# Altium schematic sheet ASI_PCB.SchDoc
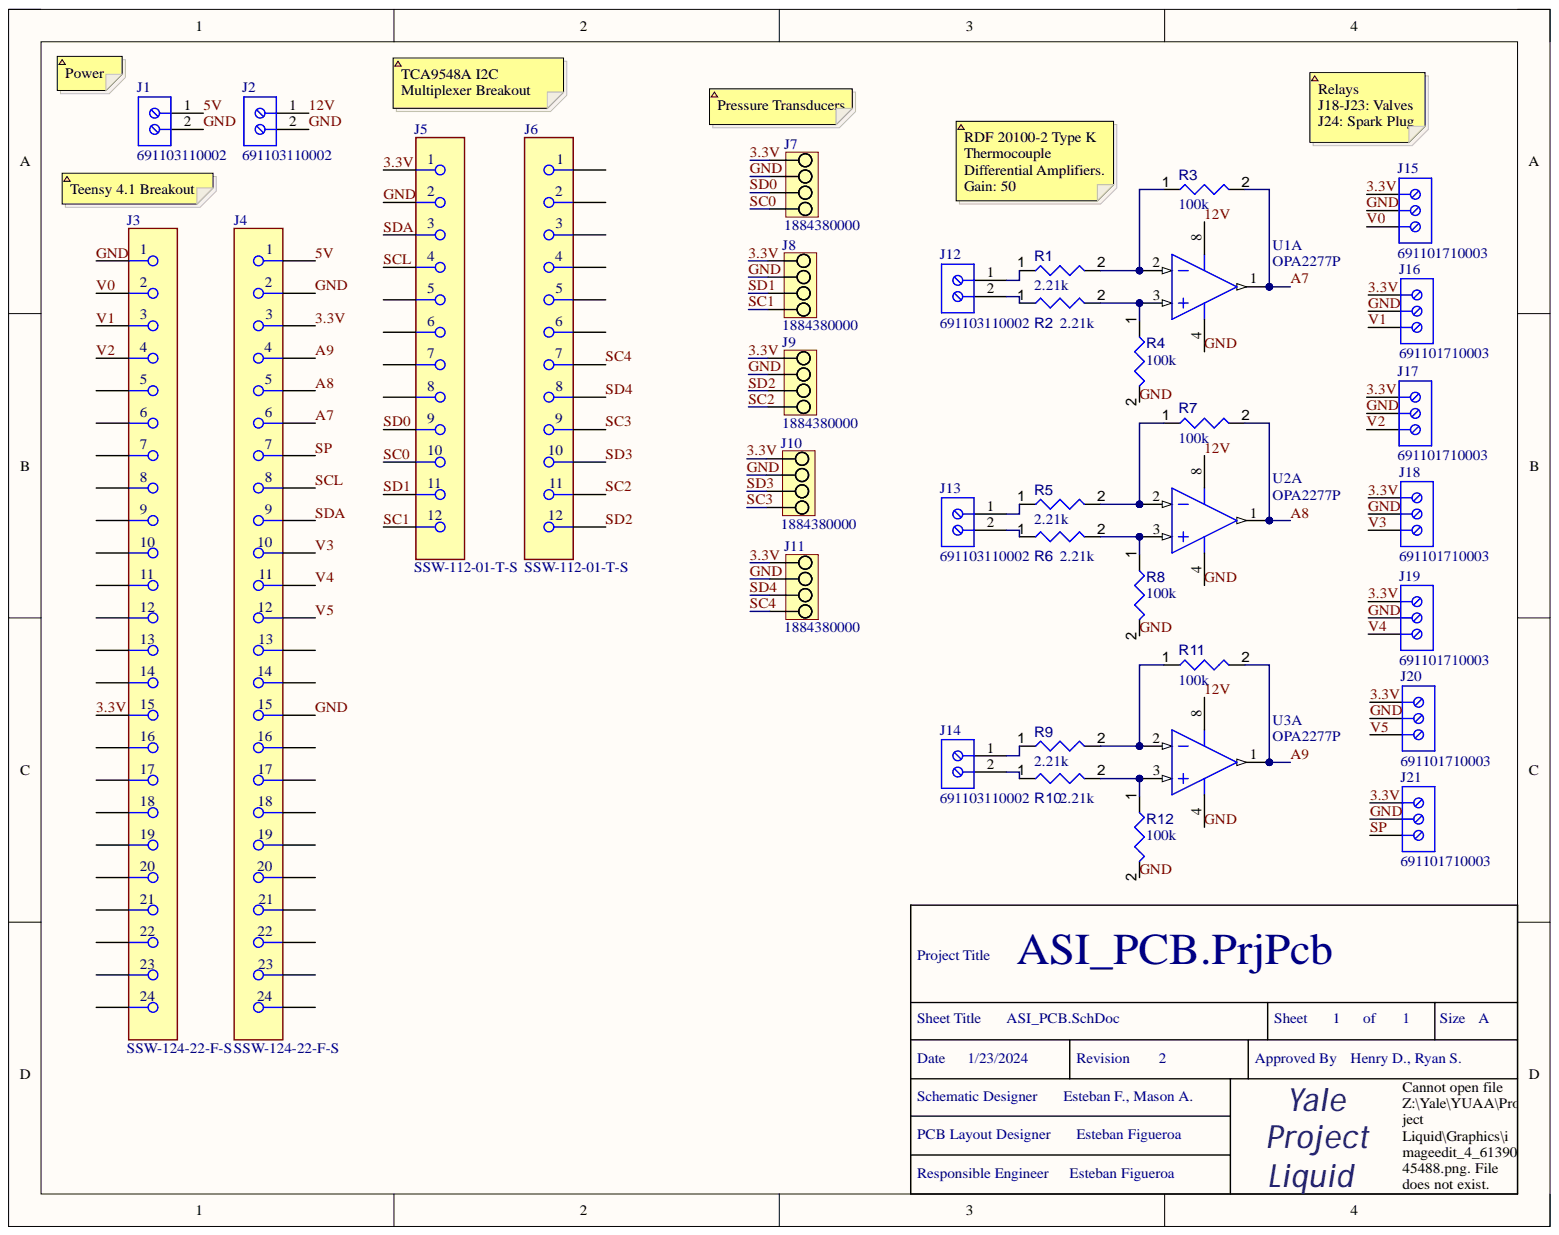
[diagram p0: ASI_PCB.SchDoc]

## Parts
J1  691103110002  [691103110002]
J2  691103110002  [691103110002]
J3  SSW-124-22-F-S  [FP-SSW-124-22-F-S-MFG]
J4  SSW-124-22-F-S  [FP-SSW-124-22-F-S-MFG]
J5  SSW-112-01-T-S  [FP-SSW-112-01-T-S-MFG]
J6  SSW-112-01-T-S  [FP-SSW-112-01-T-S-MFG]
J7  1884380000  [LSF_SMT_5_00_135_04]
J8  1884380000  [LSF_SMT_5_00_135_04]
J9  1884380000  [LSF_SMT_5_00_135_04]
J10  1884380000  [LSF_SMT_5_00_135_04]
J11  1884380000  [LSF_SMT_5_00_135_04]
J12  691103110002  [691103110002]
J13  691103110002  [691103110002]
J14  691103110002  [691103110002]
J15  691101710003  [691101710003]
J16  691101710003  [691101710003]
J17  691101710003  [691101710003]
J18  691101710003  [691101710003]
J19  691101710003  [691101710003]
J20  691101710003  [691101710003]
J21  691101710003  [691101710003]
R1  value 2.21k  [RESA60-630X240]  (hidden comment: MFR-25FBF52-2K21)
R2  value 2.21k  [RESA60-630X240]  (hidden comment: MFR-25FBF52-2K21)
R3  value 100k  [RESA60-630X240]  (hidden comment: MFR-25FBF52-100K)
R4  value 100k  [RESA60-630X240]  (hidden comment: MFR-25FBF52-100K)
R5  value 2.21k  [RESA60-630X240]  (hidden comment: MFR-25FBF52-2K21)
R6  value 2.21k  [RESA60-630X240]  (hidden comment: MFR-25FBF52-2K21)
R7  value 100k  [RESA60-630X240]  (hidden comment: MFR-25FBF52-100K)
R8  value 100k  [RESA60-630X240]  (hidden comment: MFR-25FBF52-100K)
R9  value 2.21k  [RESA60-630X240]  (hidden comment: MFR-25FBF52-2K21)
R10  value 2.21k  [RESA60-630X240]  (hidden comment: MFR-25FBF52-2K21)
R11  value 100k  [RESA60-630X240]  (hidden comment: MFR-25FBF52-100K)
R12  value 100k  [RESA60-630X240]  (hidden comment: MFR-25FBF52-100K)
U1  OPA2277P  [P0008A]  (part A)
U2  OPA2277P  [P0008A]  (part A)
U3  OPA2277P  [P0008A]  (part A)

## Nets
3.3V: J3.15 J4.3 J5.1 J7.A1 J8.A1 J9.A1 J10.A1 J11.A1 J15.1 J16.1 J17.1 J18.1 J19.1 J20.1 J21.1
5V: J1.1 J4.1
12V: J2.1 U1.8 U2.8 U3.8
A7: J4.6 R3.2 U1.1
A8: J4.5 R7.2 U2.1
A9: J4.4 R11.2 U3.1
GND: J1.2 J2.2 J3.1 J4.2 J4.15 J5.2 J7.A2 J8.A2 J9.A2 J10.A2 J11.A2 J15.2 J16.2 J17.2 J18.2 J19.2 J20.2 J21.2 R4.2 R8.2 R12.2 U1.4 U2.4 U3.4
SC0: J5.10 J7.A4
SC1: J5.12 J8.A4
SC2: J6.11 J9.A4
SC3: J6.9 J10.A4
SC4: J6.7 J11.A4
SCL: J4.8 J5.4
SD0: J5.9 J7.A3
SD1: J5.11 J8.A3
SD2: J6.12 J9.A3
SD3: J6.10 J10.A3
SD4: J6.8 J11.A3
SDA: J4.9 J5.3
SP: J4.7 J21.3
V0: J3.2 J15.3
V1: J3.3 J16.3
V2: J3.4 J17.3
V3: J4.10 J18.3
V4: J4.11 J19.3
V5: J4.12 J20.3
NetJ12_1: J12.1 R1.1
NetJ12_2: J12.2 R2.1
NetJ13_1: J13.1 R5.1
NetJ13_2: J13.2 R6.1
NetJ14_1: J14.1 R9.1
NetJ14_2: J14.2 R10.1
NetR1_2: R1.2 R3.1 U1.2
NetR2_2: R2.2 R4.1 U1.3
NetR5_2: R5.2 R7.1 U2.2
NetR6_2: R6.2 R8.1 U2.3
NetR9_2: R9.2 R11.1 U3.2
NetR10_2: R10.2 R12.1 U3.3

Not connected: J3 5 6 7 8 9 10 11 12 13 14 16 17 18 19 20 21 22 23 24; J4 13 14 16 17 18 19 20 21 22 23 24; J5 5 6 7 8; J6 1 2 3 4 5 6

## Library data of the parts
J1 J2 J12-J14 = CMP-1502-03254-2: NexarPartId: 18818286; Current: 10A; Number of Contacts: 2; Number of Levels: 1; Pitch: 3.5052mm; Plating: Steel; Stripping Length: -6mm; Voltage: 125V; Wire Gauge (Max): 26AWG; Wire Gauge (Min): 16AWG; Color: Blue; Component Kind: Standard; Contact Material: Brass, Copper, Nickel, Tin, Zinc; Contact Plating: Tin; Current Rating: 10A; Height: 8.5mm; Housing Material: Nylon; Lead Pitch: 3.5mm; Length: 7mm; Library Name: Altium Content Vault; Max Operating Temperature: 105°C; Min Operating Temperature: -40°C; Mount: Through Hole; Number of Pins: 2; Number of Positions Per Level: 2; Number of Rows: 1; Packaging: Box; REACH SVHC: Unknown; RoHS: Compliant; Termination: Screw; Voltage Rating: 125V; Width: 8mm; Wire/Cable Gauge: 26AWG
J3 J4 = CMP-00575-19585742-1: SSW-124-22-F-S Headers & Wire Housings Tiger Buy Socket Strip with PCB Tails, .100 Pitch; Manufacturer Lifecycle Status: PRODUCTION; Current Rating: 4.7A; Gender: Female; Number of Contacts: 24; Pitch: 2.54mm; Voltage Rating: 655V; Voltage Rating (AC): 465V; Color: Black; Component Kind: Standard; Contact Material: Bronze, Gold, Nickel; Contact Plating: Gold; Height: 8.64mm; Lead Free: Lead Free; Lead Pitch: 2.54mm; Library Name: Altium Content Vault; Lifecycle Status: Production; Max Operating Temperature: 125°C; Min Operating Temperature: -55°C; Mount: Through Hole; NexarPartId: 19585742; Number of Rows: 1; RoHS: Compliant; Termination: Solder; Voltage Rating (DC): 655V
J5 J6 = CMP-1023-00407-2: CONN RCPT 12POS 0.1 TIN PCB; Connector Type: Receptacle; Contact Resistance: 10mR; Mating Cycles: 100; Max Current Rating: 6.9A; Max Voltage Rating (AC): 550V; Number of Contacts: 12; Pitch: 2.54mm; Color: Black; Component Kind: Standard; Contact Material: Bronze; Contact Plating: Tin; Contact Type: Female Socket; Depth: 2.41mm; Gender: Receptacle; Housing Color: Black; Housing Material: Polymer; Lead Free: Lead Free; Length: 30.99mm; Library Name: Altium Content Vault; Max Operating Temperature: 105°C; Min Operating Temperature: -55°C; Mount: Through Hole; Number of Positions: 12; Number of Rows: 1; Orientation: Vertical; Packaging: Bulk; REACH SVHC: No SVHC; RoHS Compliant: Yes; Series: SSW; Termination: Solder; Width: 2.41mm
J7-J11 = CMP-1559-02288-1: OMNIMATE Signal - series LSF; Manufacturer: Weidmueller; Contact_material: Cu-Leg; Contact_surface: tinned; Insulating_material: LCP GF; Mounting_onto_the_PCB: Reflow solder connection; No_of_poles: 4; Pitch_inch: 0,197 inch; Pitch_mm: 5 mm; Rated_current_min_no_of_poles_TU_20_deg_C: 19 A; Rated_current_use_group_B_UL_1059: 12 A; Rated_voltage_for_surge_voltage_class_pollution_degree_II_2: 500 V; Rated_voltage_use_group_B_UL_1059: 300 V; Short_text_for_material: LSF-SMT 5.00/04/135 3.5SN BK TU; Side_termination_characteristic: closed side; Solder_pin_length_mm: 3,5 mm; Solid_max_H05_07_V_U: 1,5 mm²; Solid_min_H05_07_V_U: 0,2 mm²; UL_94_flammability_rating: V-0; Wire_cross_section_AWG_max_UL_1059: AWG 16; Wire_cross_section_AWG_min_UL_1059: AWG 24; Colour: Black; Component Kind: Standard; ComponentLink1Description: Manufacturer URL; ComponentLink1URL: http://www.weidmueller.com/; ComponentLink2Description: URL Link1; ComponentLink2URL: http://catalog.weidmueller.com/catalog/Start.do?localeId=en&ObjectID=1884380000; Library Name: Altium Content Vault; PackageReference: LSF_SMT_5_00_135_04; Packaging: Tube; PartNumber: 1884380000; Qty: 28 PCS; Revision: V1
J15-J21 = CMP-1502-03257-2: NexarPartId: 8917705; Current: 16A; Number of Contacts: 3; Number of Levels: 1; Pitch: 5.0038mm; Plating: Steel; Stripping Length: -7mm; Voltage: 250V; Wire Gauge (Max): 26AWG; Wire Gauge (Min): 14AWG; Color: Blue; Component Kind: Standard; Contact Material: Brass; Contact Plating: Tin; Current Rating: 16A; Height: 16.4mm; Housing Material: Nylon; Lead Pitch: 5mm; Length: 9mm; Library Name: Altium Content Vault; Max Operating Temperature: 105°C; Min Operating Temperature: -40°C; Mount: Through Hole; Number of Pins: 3; Number of Positions Per Level: 3; Packaging: Box; REACH SVHC: Unknown; RoHS: Compliant; Termination: Screw; Voltage Rating: 250V; Width: 16.2mm; Wire/Cable Gauge: 26AWG
R1 R2 R5 R6 R9 R10 = CMP-009-00054-4: Axial Resistor, 2.21 KOhm, +/- 1%, 0.25 W, -55 to 155 degC, 2-Pin THD, RoHS, Bulk; Manufacturer: Yageo; Power: 250mW; Voltage Rating: 250V; Case/Package: Axial; Component Kind: Standard; Datasheet URL: http://www.yageo.com/documents/recent/Yageo%20LR_MFR_2013.pdf; Datasheet Version: Apr-13; Manufacturer URL: http://www.yageo.com/; Max Operating Temperature: 155°C; Min Operating Temperature: -55°C; Mounting Technology: TH; Package Description: 2-Pin Axial Lead, Dia 2.4 mm, Body Length 6.3 mm; Package Quantity: 200; Package Reference: MFR-25; Packaging: Bulk; RoHS Compliant: True; Tolerance: 1%; Library Name: Yale Project Liquid
R3 R4 R7 R8 R11 R12 = CMP-009-00045-4: Axial Resistor, 100 KOhm, +/- 1%, 0.25 W, -55 to 155 degC, 2-Pin THD, RoHS, Bulk; Manufacturer: Yageo; Power: 250mW; Voltage Rating: 250V; Case/Package: Axial; Component Kind: Standard; Datasheet URL: http://www.yageo.com/documents/recent/Yageo%20LR_MFR_2013.pdf; Datasheet Version: Apr-13; Manufacturer URL: http://www.yageo.com/; Max Operating Temperature: 155°C; Min Operating Temperature: -55°C; Mounting Technology: TH; Package Description: 2-Pin Axial Lead, Dia 2.4 mm, Body Length 6.3 mm; Package Quantity: 200; Package Reference: MFR-25; Packaging: Bulk; RoHS Compliant: True; Tolerance: 1%; Library Name: Yale Project Liquid
U1-U3 = CMP-0272-01558-3: High Precision Operational Amplifier, 4 to 36 V, -40 to 85 degC, 8-pin DIP (P8), Green (RoHS & no Sb/Br); Manufacturer: Texas Instruments; CMRR(Min)(dB): 130; GBW(Typ)(MHz): 1; IIB(Max)(pA): 1000; Iq per channel(Max)(mA): 0.825; Number of Channels: 2; Offset Drift(Typ)(uV/C): 0.1; Packing: TUBE; Rating: Catalog; Slew Rate(Typ)(V/us): 0.8; Sub Family: High Supply Voltage Amplifier (>=30V), Low Noise Amp (<=10nV/rtHz), Low Offset Voltage Amplifier (<=500uV), Precision Amplifier; Total Supply Voltage(Max)(+5V is 5, +/-5V is 10): 36; Total Supply Voltage(Min)(+5V is 5, +/-5V is 10): 4; Vio (25C)(Max)(mV): 0.025; Code_JEDEC: MS-001; Component Kind: Standard; ComponentLink1Description: Manufacturer URL; ComponentLink1URL: http://www.ti.com/; ComponentLink2Description: Datasheet; ComponentLink2URL: http://www.ti.com/lit/ds/symlink/opa2277.pdf; ComponentLink3Description: Package Specification; ComponentLink3URL: http://www.ti.com/litv/pdf/mpdi001b; DatasheetVersion: SBOS079A; Eco-Plan: Green (RoHS & no Sb/Br); Library Name: Altium Content Vault; Mounting Technology: Through Hole; PackageDescription: 8-Pin Plastic In-Line, Body 9.59 x 6.35 mm, Pitch 2.54 mm; PackageReference: P0008A; PackageVersion: 4040082/E, 04/2010; PartNumber: OPA2277P
